# Altium schematic sheet Sensor-Sheet1.SchDoc(Sensor-Sheet1)
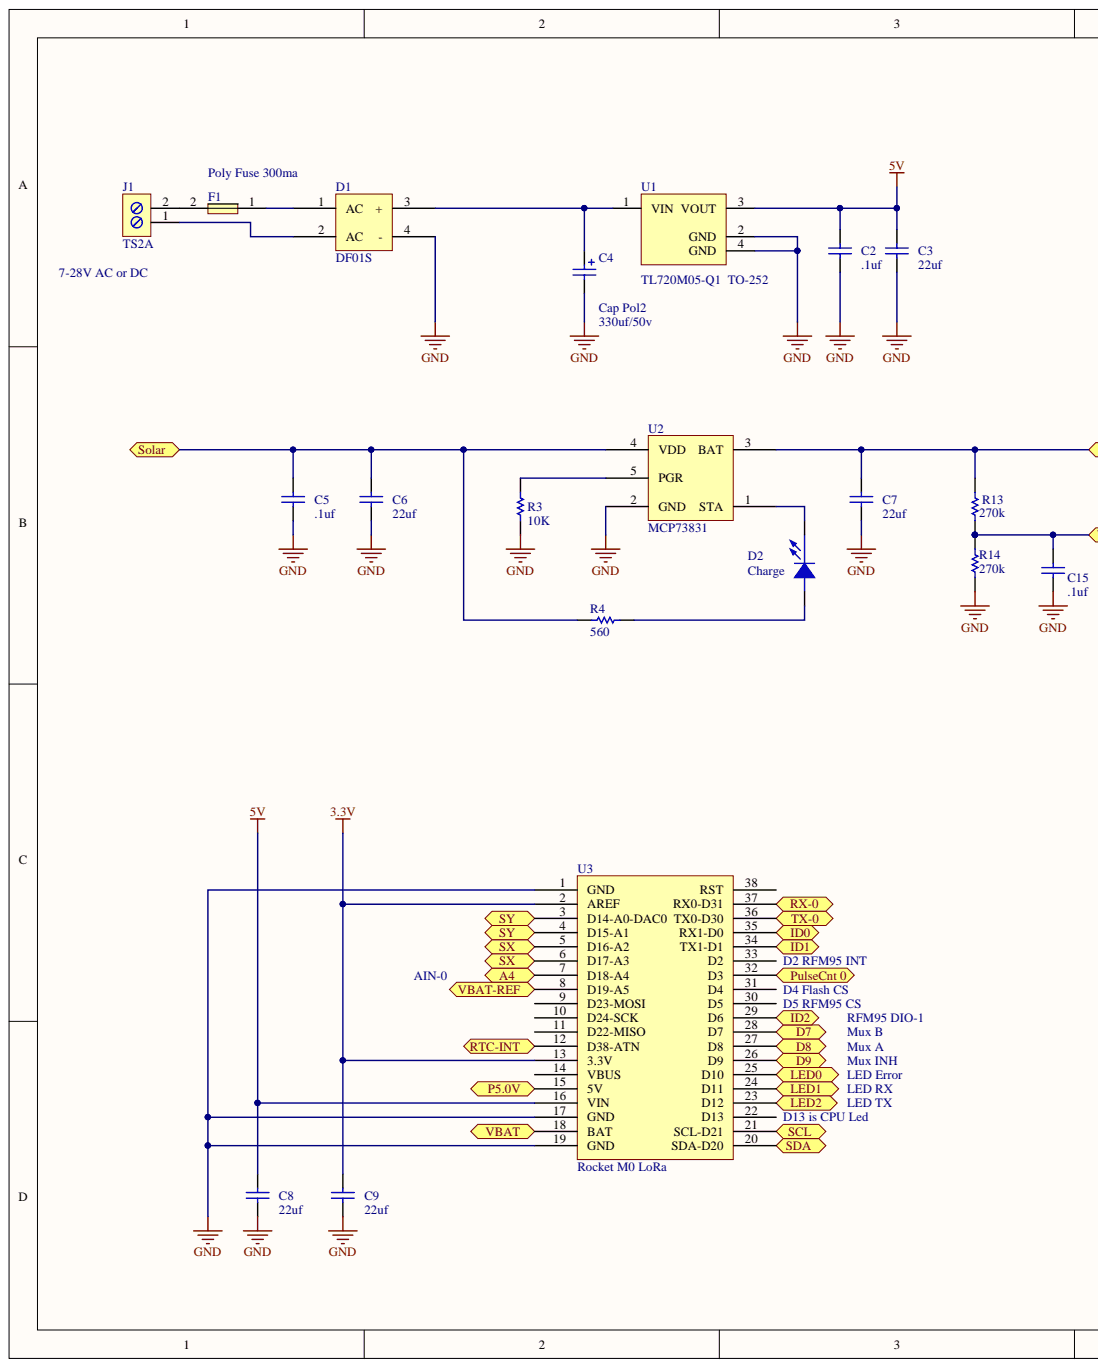
[diagram p0: Sensor-Sheet1.SchDoc, left]
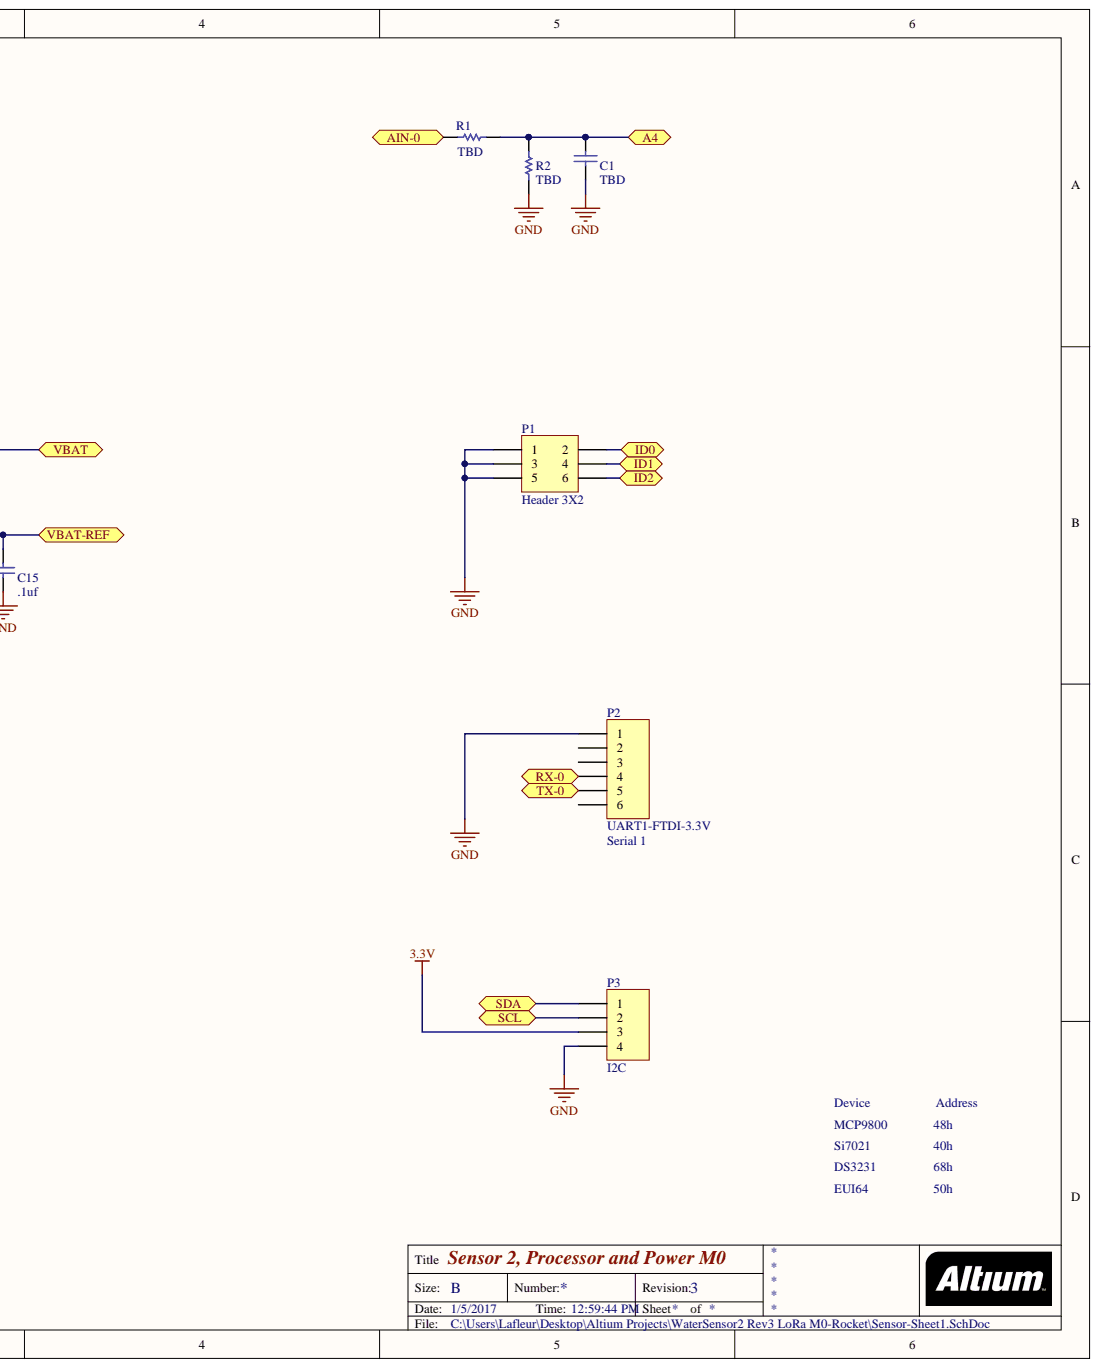
[diagram p1: Sensor-Sheet1.SchDoc, right]

## Parts
C1  TBD  [0805]
C2  .1uf  [0805]
C3  22uf  [C1206]
C4  Cap Pol2  value 330uf/50v  [Cap-Axial 13x5.4]
C5  .1uf  [0805]
C6  22uf  [C1206]
C7  22uf  [C1206]
C8  22uf  [C1206]
C9  22uf  [C1206]
C15  .1uf  [0805]
D1  DF01S  [SOP4-Bridge]
D2  Charge  [LED 0805]
F1  Poly Fuse 300ma  [PTC 2016L]
J1  TS2A  [TS3.81X2]
P1  Header 3X2  [HDR2X3]
P2  UART1-FTDI-3.3V  [HDR1X6]
P3  I2C  [HDR1X4]
R1  TBD  [6-0805]
R2  TBD  [6-0805]
R3  10K  [6-0805]
R4  560  [6-0805]
R13  270k  [6-0805]
R14  270k  [6-0805]
U1  TL720M05-Q1  TO-252  [SOT-223/TO-252 - Extended-Pad]
U2  MCP73831  [SOT-23-OT5_L]
U3  Rocket M0 LoRa  [Rocket-DIP38-900mil]

## Ports
A4, AIN-0, D7, D8, D9, ID0, ID1, ID2, LED0, LED1, LED2, P5.0V, PULSECNT 0, RTC-INT, RX-0, SCL, SDA, SOLAR, SX, SY, TX-0, VBAT, VBAT-REF

## Nets
3.3V: C9.1 P3.3 U3.2(AREF) U3.13(3.3V)
5V: C2.1 C3.1 C8.1 U1.3(VOUT) U3.16(VIN)
GND: C1.2 C2.2 C3.2 C4.2 C5.2 C6.2 C7.2 C8.2 C9.2 C15.2 D1.4(-) P1.1 P1.3 P1.5 P2.1 P3.4 R2.1 R3.1 R14.1 U1.2(GND) U1.4(GND) U2.2(GND) U3.1(GND) U3.17(GND) U3.19(GND)
A4: C1.1 R1.2 R2.2 U3.7(D18-A4) ; port A4
AIN-0: R1.1 ; port AIN-0
D7: U3.28(D7) ; port D7
D8: U3.27(D8) ; port D8
D9: U3.26(D9) ; port D9
ID0: P1.2 U3.35(RX1-D0) ; port ID0
ID1: P1.4 U3.34(TX1-D1) ; port ID1
ID2: P1.6 U3.29(D6) ; port ID2
LED0: U3.25(D10) ; port LED0
LED1: U3.24(D11) ; port LED1
LED2: U3.23(D12) ; port LED2
P5.0V: U3.15(5V) ; port P5.0V
RTC-INT: U3.12(D38-ATN) ; port RTC-INT
RX-0: P2.4 U3.37(RX0-D31) ; port RX-0
SCL: P3.2 U3.21(SCL-D21) ; port SCL
SDA: P3.1 U3.20(SDA-D20) ; port SDA
SX: U3.5(D16-A2) U3.6(D17-A3) ; port SX
SY: U3.3(D14-A0-DAC0) U3.4(D15-A1) ; port SY
TX-0: P2.5 U3.36(TX0-D30) ; port TX-0
VBAT: C7.1 R13.1 U2.3(BAT) U3.18(BAT) ; port VBAT
VBAT-REF: C15.1 R13.2 R14.2 U3.8(D19-A5) ; port VBAT-REF
NetC4_1: C4.1 D1.3(+) U1.1(VIN)
NetC5_1: C5.1 C6.1 R4.2 U2.4(VDD) ; port SOLAR
NetD1_1: D1.1(AC) F1.1
NetD1_2: D1.2(AC) J1.1
NetD2_1: D2.1 R4.1
NetD2_2: D2.2 U2.1(STA)
NetF1_2: F1.2 J1.2
NetP2_2: P2.2
NetP2_3: P2.3
NetP2_6: P2.6
NetR3_2: R3.2 U2.5(PGR)
NetU3_9: U3.9(D23-MOSI)
NetU3_10: U3.10(D24-SCK)
NetU3_11: U3.11(D22-MISO)
NetU3_14: U3.14(VBUS)
NetU3_22: U3.22(D13)
NetU3_30: U3.30(D5)
NetU3_31: U3.31(D4)
NetU3_32: U3.32(D3) ; port PULSECNT 0
NetU3_33: U3.33(D2)
NetU3_38: U3.38(RST)

## Library data of the parts
C1-C3 C5-C9 C15 = CAP_1: Capacitor; Fitted: True; Component Kind: Standard; Library Name: N2K Project.SCHLIB
C4 = Cap Pol2: Polarized Capacitor (Axial); Component Kind: Standard; LatestRevisionDate: 17-Jul-2002; LatestRevisionNote: Re-released for DXP Platform.; Library Name: Miscellaneous Devices.IntLib; PackageDescription: Polarized Capacitor; 2 Leads; PackageReference: POLAR0.8; Published: 8-Jun-2000; Signal Integrity: Cap Pol; Simulation: CAP; Publisher: Altium Limited
D1 = Bridge DF01S: Component Kind: Standard; Library Name: WaterSensor.SCHLIB
D2 = LTST150: Part Description: SMT LED Green; Vendor Part Number: LTST-C150GKRT; DEVICE: C150; Flex_PN: D20000; Vendor: LITEON; Component Kind: Standard; Library Name: Winmor-Demo-R1.IntLib
F1 = Poly Fuse: Component Kind: Standard; Description: Poly Fuse; Library Name: N2K Project.SCHLIB
J1 = TS2A: Screw Terminal, 3.81mm (.15in) 2X; Component Kind: Standard; Library Name: WaterSensor_1.SCHLIB
P1 = Header 3X2: Header, 3-Pin, Dual row; Component Kind: Standard; LatestRevisionDate: 17-Jul-2002; LatestRevisionNote: Re-released for DXP Platform.; Library Name: Miscellaneous Connectors.IntLib; Signal Integrity: Connector; Publisher: Altium Limited
P2 = Header 6: Header, 6-Pin; Component Kind: Standard; LatestRevisionDate: 17-Jul-2002; LatestRevisionNote: Re-released for DXP Platform.; Signal Integrity: Connector; Library Name: BG-Barometric-Sensor Rev5 802.SCHLIB; Publisher: Altium Limited
P3 = Header 4: Header, 4-Pin; Component Kind: Standard; LatestRevisionDate: 17-Jul-2002; LatestRevisionNote: Re-released for DXP Platform.; Library Name: Miscellaneous Connectors.IntLib; Signal Integrity: Connector; Publisher: Altium Limited
R1-R4 R13 R14 = ERJ8EN: Precision Thick Film Chip Resistor, 10 Ohm to 2.2M Ohm Range, 1% Tolerance, 1206 Size, 0.125 W; Code_IPC: 3216 [1206]; Component Kind: Standard; ComponentLink1Description: Manufacturer Link; ComponentLink1URL: http://www.panasonic.com/industrial/components/resistive_products.htm; ComponentLink2Description: Datasheet; ComponentLink2URL: http://www.panasonic.com/industrial/components/pdf/AOA0000CE2.pdf; LatestRevisionDate: 19-Mar-2004; LatestRevisionNote: Silkscreen size reduced.; Library Name: N2K Project.SCHLIB; PackageDocument: Mar-2003; PackageReference: 8-1206; Published: 17-Dec-2003; Signal Integrity: Res; Simulation: RESISTOR; Publisher: Altium Limited
U1 = TC1262-3.3VDBTR: 500mA Fixed Output CMOS LDO, 3-Pin SOT-223, Extended Temperature, Tape and Reel; Component Kind: Standard; ComponentLink1Description: Datasheet; ComponentLink1URL: http://ww1.microchip.com/downloads/en/DeviceDoc/21373b.pdf; ComponentLink2Description: Packaging Specification; ComponentLink2URL: http://www.microchip.com/stellent/groups/techpub_sg/documents/packagingspec/en012702.pdf; ComponentLink3Description: Microchip.com Home; ComponentLink3URL: http://www.microchip.com/; DatasheetVersion: revB, 2002; PackageDescription: 3-Lead Plastic Small Outline Transistor (DB) [SOT-223]; PackageReference: SOT-223-DB3; PackageVersion: revBB, Aug-2009; Published: 29-Jan-2009; Supplier 1: Digi-Key; Supplier 2: Digi-Key; Supplier 3: Digi-Key; Supplier 4: Farnell; Supplier Part Number 1: TC1262-3.3VDBTR-ND; Supplier Part Number 2: TC1262-3.3VDBCT-ND; Supplier Part Number 3: TC1262-3.3VDBDKR-ND; Supplier Part Number 4: 1296594; Library Name: Winmor-Demo-R2.IntLib; Publisher: Altium Limited
U2 = MCP7381: MCP73831 Li-Ion, Li-Polymer Charger; Component Kind: Standard; Library Name: WaterSensor_5.SCHLIB
U3 = Rocket M0 LoRa: Component Kind: Standard; Description: Rocket M0 LoRa; Library Name: WaterSensor 2_1.SCHLIB
